# Altium schematic sheet controller.SchDoc(controller)
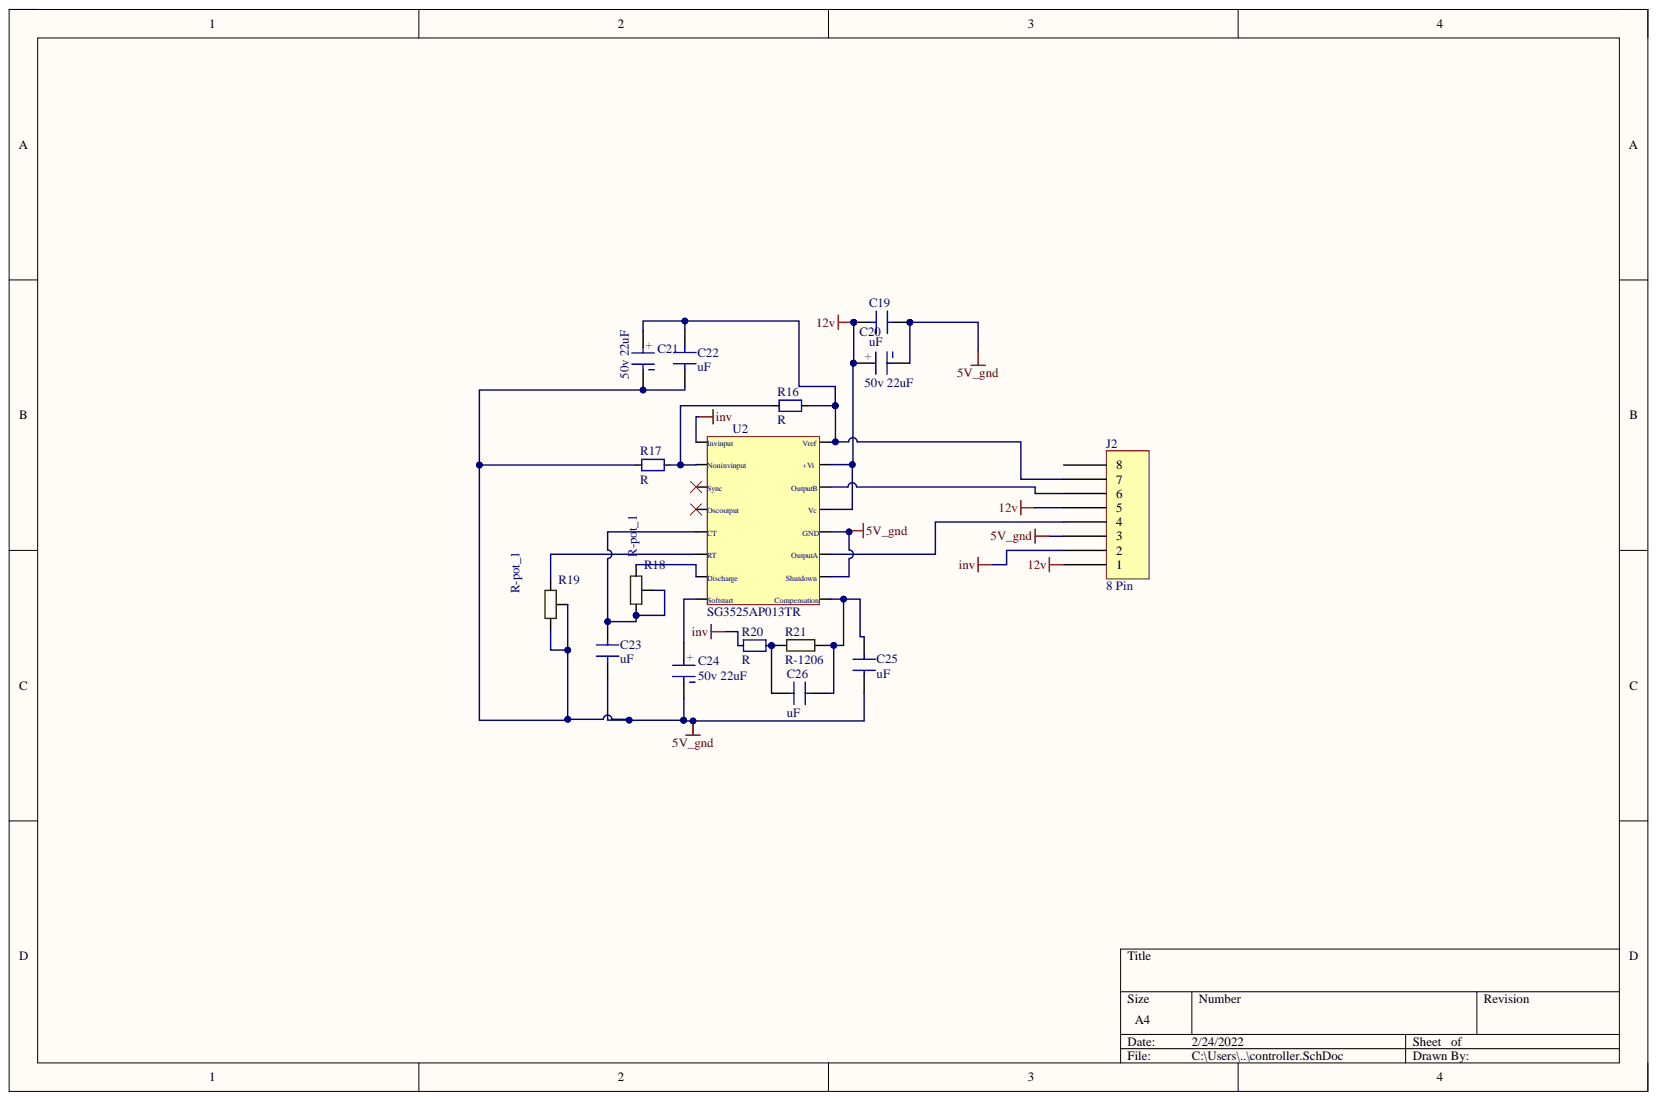
[diagram p0: controller.SchDoc]

## Parts
C19  uF  [C-1206]
C20  50v 22uF  [50v 22uf]
C21  50v 22uF  [50v 22uf]
C22  uF  [C-1206]
C23  uF  [C-1206]
C24  50v 22uF  [50v 22uf]
C25  uF  [C-1206]
C26  uF  [C-1206]
J2  8 Pin  [8 pin connector]
R16  R  [R-0805R]
R17  R  [R-0805R]
R18  R-pot_1  [pot]
R19  R-pot_1  [pot]
R20  R  [R-0805R]
R21  R-1206  [R-1206]
U2  SG3525AP013TR  [SOIC16N SG3525]

## Nets
5V_gnd: C19.1 C20.2 C21.2 C22.2 C23.1 C24.2 C25.2 J2.3 R17.1 R19.1 R19.2 U2.10 U2.12
12v: C19.2 C20.1 J2.1 J2.5 U2.13 U2.15
inv: J2.2 R20.1 U2.1
NetC21_1: C21.1 C22.1 J2.7 R16.2 U2.16
NetC23_2: C23.2 R18.1 R18.2 U2.5
NetC24_1: C24.1 U2.8
NetC25_1: C25.1 C26.2 R21.2 U2.9
NetC26_1: C26.1 R20.2 R21.1
NetJ2_4: J2.4 U2.11
NetJ2_6: J2.6 U2.14
NetR16_1: R16.1 R17.2 U2.2
NetR18_3: R18.3 U2.7
NetR19_3: R19.3 U2.6

Not connected: J2 8; U2 3 4

## Library data of the parts
C19 C22 C23 C25 C26 = C-1206: Component Kind: Standard; Library Name: SMPSProject.SchLib
C20 C21 C24 = 50v 22uF: Manufacturer: Nichicon; Manufacturer Part Number: LKS1J472MESZ; Capacitance: 4.7mF; Life (Hours): 1000Hour; Ripple Current: 3A; Tolerance: 20%; Voltage Rating: 63V; Case/Package: Radial; Component Kind: Standard; ComponentLink3Description: Link; ComponentLink3URL: https://ozdisan.com/passive-components/capacitors/aluminum-capacitors/MRW-050V220ME057-TR; Diameter: 22mm; Height - Seated (Max): 1.772inch; Lead Pitch: 0.394inch; Length: 45mm; Max Operating Temperature: 85°C; Min Operating Temperature: -40°C; Mount: Through Hole; Package Quantity: 250; Packaging: Bulk; RoHS: Yes; Supplier 1: Mouser; Supplier Part Number 1: 647-LKS1J472MESZ; Termination: Snap in; Voltage Rating (DC): 63V; Library Name: SMPSProject.SchLib
R16 R17 R20 = R 0805: Component Kind: Standard; Library Name: SMPSProject.SchLib
R18 R19 = R-pot_1: Res1206; Component Kind: Standard; Library Name: SMPSProject.SchLib
R21 = R-1206: Res1206; Component Kind: Standard; Library Name: SMPSProject.SchLib
U2 = SG3525AP013TR: IC REG CTRLR BCK/PSH-PULL 16SOIC; Manufacturer: STMicroelectronics; Duty Cycle: 49%; Fall Time: 50ns; Max Frequency: 500kHz; Max Supply Current: 20mA; Max Supply Voltage: 35V; Number of Outputs: 2; Operating Supply Current: 14mA; Output Current: 400A; Output Voltage: 5.2V; Rise Time: 100ns; Switching Frequency: 400kHz; Case/Package: SOIC; Component Kind: Standard; ComponentLink1Description: http://www.st.com/content/ccc/resource/technical/document/datasheet/c0/12/98/4a/3b/1a/4b/8b/CD00000958.pdf/files/CD00000958.pdf/jcr:content/translations/en.CD00000958.pdf?src-supplier=Digi-Key; ComponentLink1URL: http://www.st.com/content/ccc/resource/technical/document/datasheet/c0/12/98/4a/3b/1a/4b/8b/CD00000958.pdf/files/CD00000958.pdf/jcr:content/translations/en.CD00000958.pdf?src-supplier=Digi-Key; ComponentLink2Description: https://www.verical.com/server-webapp/html/getDatasheet?partID=376579&src-supplier=Verical; ComponentLink2URL: https://www.verical.com/server-webapp/html/getDatasheet?partID=376579&src-supplier=Verical; ComponentLink3Description: http://www.produktinfo.conrad.com/datenblaetter/1100000-1199999/001185611-da-01-en-IC_REG_CTRLR_P_SG3525AP013TR_SOIC_16_STM.pdf?src-supplier=Conrad; ComponentLink3URL: http://www.produktinfo.conrad.com/datenblaetter/1100000-1199999/001185611-da-01-en-IC_REG_CTRLR_P_SG3525AP013TR_SOIC_16_STM.pdf?src-supplier=Conrad; Lead Free: Lead Free; Manufacturer Part Number: SG3525AP013TR; Max Duty Cycle: 49%; Max Operating Temperature: 70°C; Min Operating Temperature: 0°C; Mount: Surface Mount; Number of Pins: 16; Package Quantity: 1; Packaging: Tape and Reel; Pricing 1: 1 = 1,58000, 10 = 1,41600, 100 = 1,10400, 500 = 0,91196, 1000 = 0,71997 (USD); Radiation Hardening: No; RoHS: Yes; Stock 1: 5712; Supplier 1: Digi-Key; Supplier Part Number 1: 497-6479-1-ND; Weight: 0.007079oz; Library Name: SMPSProject.SchLib
J2 = 8 Pin: Component Kind: Standard; Library Name: SMPSProject.SchLib
